# Altium schematic sheet Alimentation.SchDoc
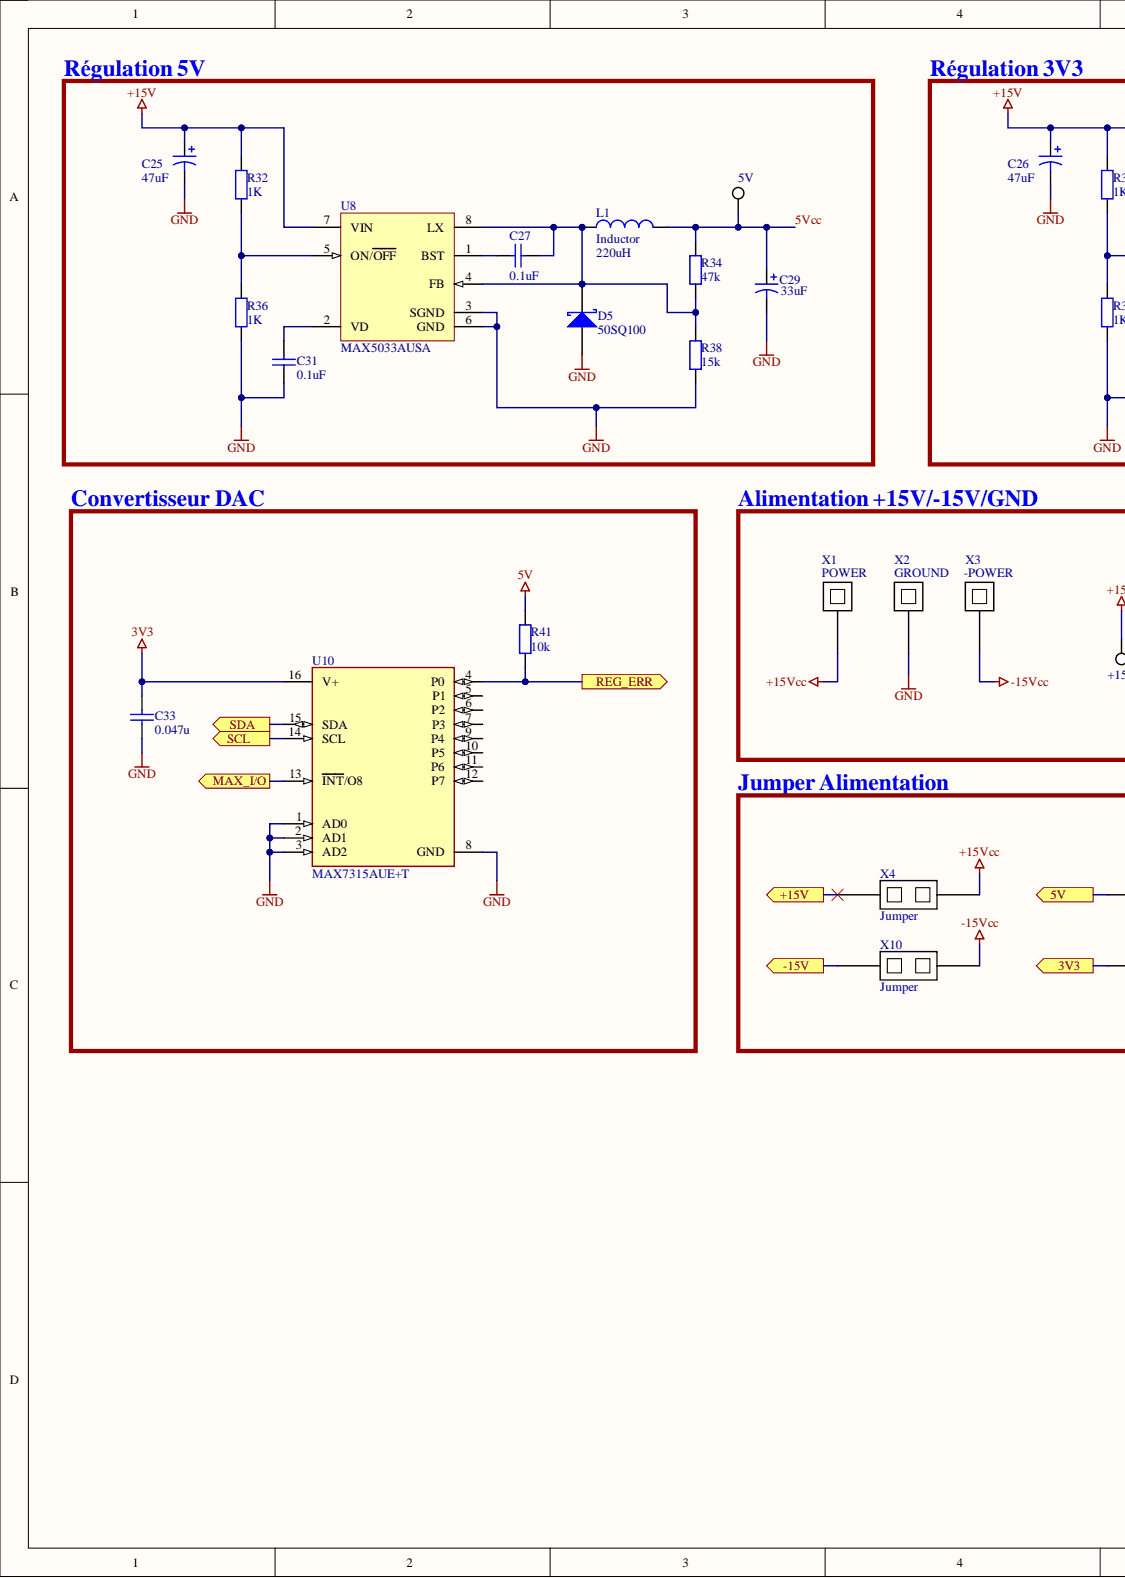
[diagram p0: Alimentation.SchDoc, left]
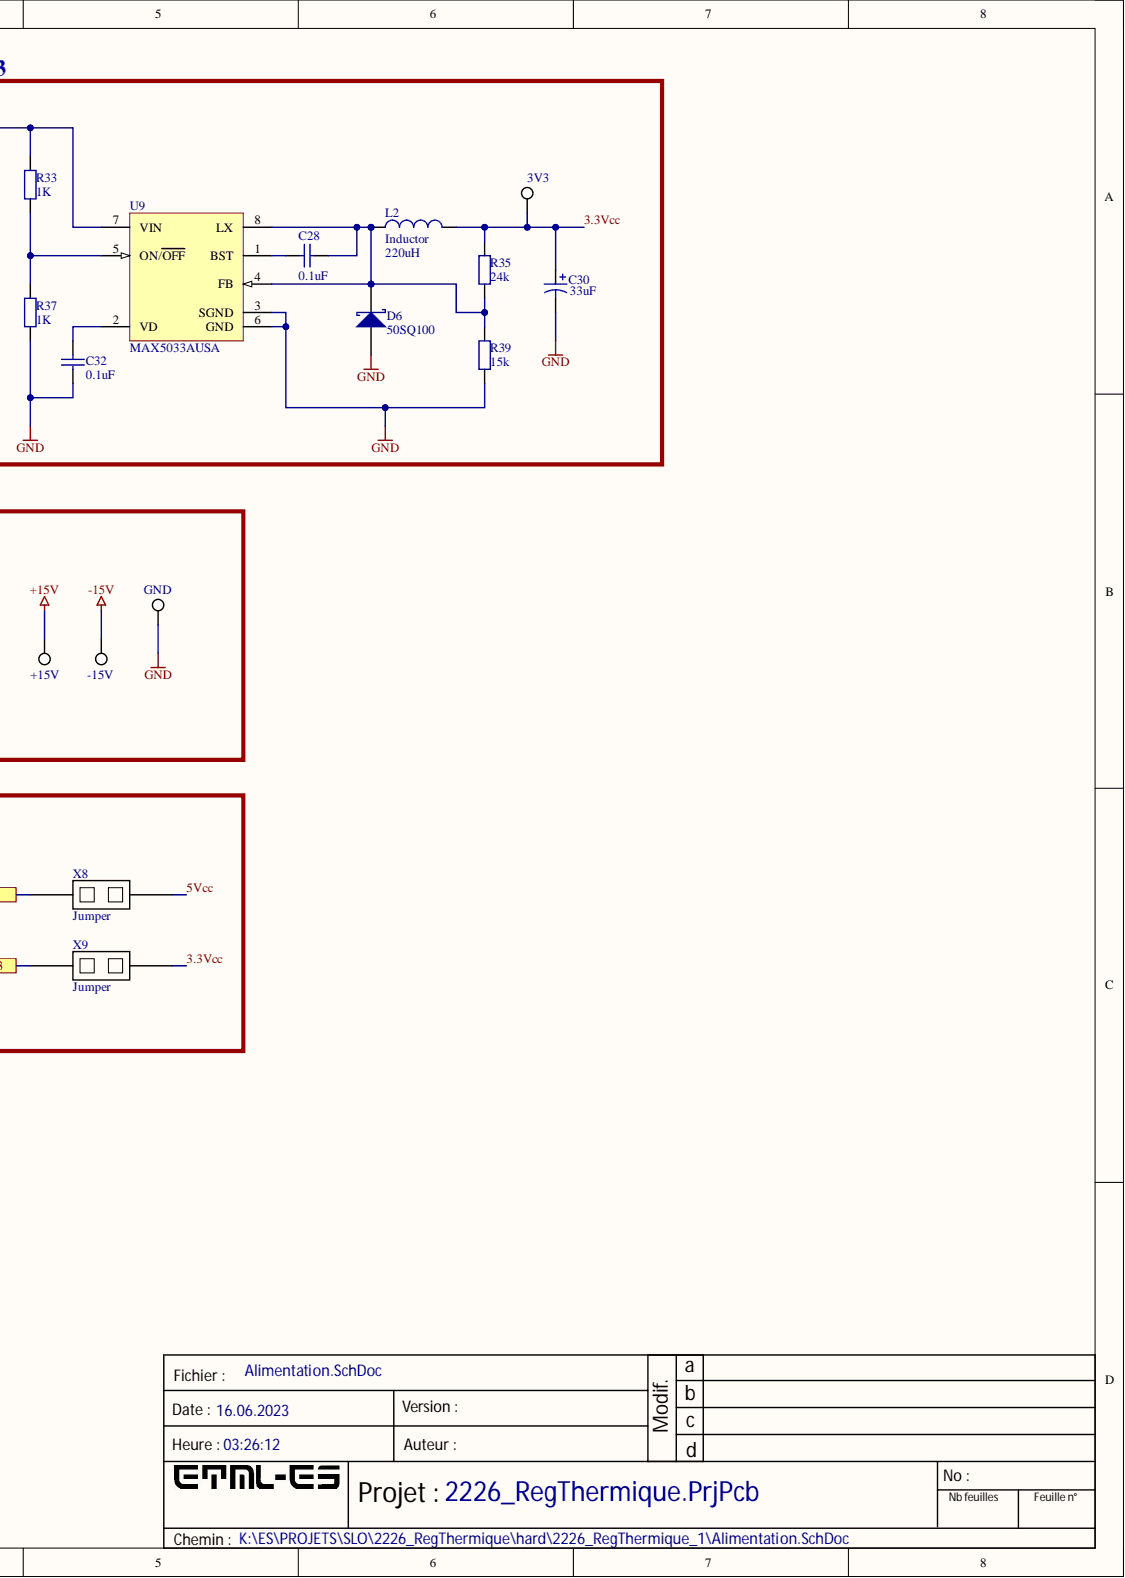
[diagram p1: Alimentation.SchDoc, right]

## Parts
3V3  REGP  [KSTN-5019_V]  (value not drawn)
5V  REGP  [KSTN-5019_V]  (value not drawn)
+15V  REGP  [KSTN-5019_V]  (value not drawn)
-15V  REGP  [KSTN-5019_V]  (value not drawn)
C25  value 47uF  [C0805]  (hidden comment: Cap Pol1)
C26  value 47uF  [C0805]  (hidden comment: Cap Pol1)
C27  value 0.1uF  [C0805]  (hidden comment: Cap)
C28  value 0.1uF  [C0805]  (hidden comment: Cap)
C29  value 33uF  [C0805]  (hidden comment: Cap Pol1)
C30  value 33uF  [C0805]  (hidden comment: Cap Pol1)
C31  value 0.1uF  [C0805]  (hidden comment: Cap)
C32  value 0.1uF  [C0805]  (hidden comment: Cap)
C33  value 0.047u  [C0805]  (hidden comment: Cap)
D5  50SQ100  [SODFL2513X70N]
D6  50SQ100  [SODFL2513X70N]
GND  REGP  [KSTN-5019_V]  (value not drawn)
L1  Inductor  value 220uH  [SDE0604A101K]
L2  Inductor  value 220uH  [SDE0604A101K]
R32  value 1K  [6-0805_N]  (hidden comment: Res2)
R33  value 1K  [6-0805_N]
R34  value 47k  [6-0805_N]  (hidden comment: Res2)
R35  value 24k  [6-0805_N]  (hidden comment: Res2)
R36  value 1K  [6-0805_N]  (hidden comment: Res2)
R37  value 1K  [6-0805_N]
R38  value 15k  [6-0805_N]  (hidden comment: Res2)
R39  value 15k  [6-0805_N]  (hidden comment: Res2)
R41  value 10k  [6-0805_N]  (hidden comment: Res2)
U8  MAX5033AUSA  [S8-5_N]
U9  MAX5033AUSA  [S8-5_N]
U10  MAX7315AUE+T  [FP-U16-2-MFG]
X1  POWER  [PIN1]
X2  GROUND  [PIN1]
X3  -POWER  [PIN1]
X4  Jumper  [HDR1X2]
X8  Jumper  [HDR1X2]
X9  Jumper  [HDR1X2]
X10  Jumper  [HDR1X2]

## Ports
3V3, 5V, +15V, -15V, MAX_I/O, REG_ERR, SCL, SDA

## Nets
3V3: C33.2 U10.16(V+)
5V: R41.2
+15V: +15V.1 C25.1 C26.1 R32.2 R33.2 U8.7(VIN) U9.7(VIN)
+15Vcc: X1.1 X4.2
-15V: -15V.1
-15Vcc: X3.1 X10.2
GND: C25.2 C26.2 C29.2 C30.2 C31.2 C32.2 C33.1 D5.1 D6.1 GND.1 R36.1 R37.1 R38.1 R39.1 U8.3(SGND) U8.6(GND) U9.3(SGND) U9.6(GND) U10.1(AD0) U10.2(AD1) U10.3(AD2) U10.8(GND) X2.1
3.3Vcc: 3V3.1 C30.1 L2.2 R35.2 X9.2
5Vcc: 5V.1 C29.1 L1.2 R34.2 X8.2
MAX_I/O: U10.13(INT/O8) ; port MAX_I/O
REG_ERR: R41.1 U10.4(P0) ; port REG_ERR
SCL: U10.14(SCL) ; port SCL
SDA: U10.15(SDA) ; port SDA
NetC27_1: C27.1 U8.1(BST)
NetC27_2: C27.2 D5.2 L1.1 R34.1 R38.2 U8.4(FB) U8.8(LX)
NetC28_1: C28.1 U9.1(BST)
NetC28_2: C28.2 D6.2 L2.1 R35.1 R39.2 U9.4(FB) U9.8(LX)
NetC31_1: C31.1 U8.2(VD)
NetC32_1: C32.1 U9.2(VD)
NetR32_1: R32.1 R36.2 U8.5(ON/OFF)
NetR33_1: R33.1 R37.2 U9.5(ON/OFF)
NetX4_1: X4.1
NetX8_1: X8.1 ; port 5V
NetX9_1: X9.1 ; port 3V3
NetX10_1: X10.1

Not connected: U10 5(P1) 6(P2) 7(P3) 9(P4) 10(P5) 11(P6) 12(P7)

## Library data of the parts
3V3 5V +15V -15V GND = CMP-1672-00008-1: Test Point, 1 Position SMD, RoHS, Tape and Reel; Component Kind: Standard; ComponentLink1Description: Manufacturer URL; ComponentLink1URL: http://www.keyelco.com/; ComponentLink2Description: Datasheet; ComponentLink2URL: http://www.keyelco.com/product-pdf.cfm?p=1357; Contact Plating: Silver; DatasheetVersion: 03/2014; Library Name: Altium Content Vault; Mount: Surface Mount; Mounting Technology: Surface Mount; Package Quantity: 1000; PackageDescription: 1-Pin Surface Mount Device, Body 3.81 x 2.03 mm; PackageReference: 5019; Packaging: Tape and Reel; Pins: 1; RoHSCompliant: True
C25 C26 = Cap Pol1: Cap Pol 47uF; Component Kind: Standard; LatestRevisionDate: 17-Jul-2002; LatestRevisionNote: Re-released for DXP Platform.; Library Name: Miscellaneous Devices.IntLib; PackageDescription: Polarized Capacitor (Radial); 2 Leads; PackageReference: RB7.6-15; Published: 8-Jun-2000; Signal Integrity: Cap Pol; Simulation: CAP; Publisher: Altium Limited
C27 C28 C31 C32 = Cap: Cap 100nF; Component Kind: Standard; LatestRevisionDate: 17-Jul-2002; LatestRevisionNote: Re-released for DXP Platform.; Library Name: Miscellaneous Devices.IntLib; PackageDescription: Radial Cap, Thru-Hole; 2 Leads; 0.3 in Pin Spacing; PackageReference: RAD-0.3; Published: 8-Jun-2000; Signal Integrity: Cap; Simulation: CAP; Publisher: Altium Limited
C29 C30 = Cap Pol1: Cap Pol 33uF; Component Kind: Standard; LatestRevisionDate: 17-Jul-2002; LatestRevisionNote: Re-released for DXP Platform.; Library Name: Miscellaneous Devices.IntLib; PackageDescription: Polarized Capacitor (Radial); 2 Leads; PackageReference: RB7.6-15; Published: 8-Jun-2000; Signal Integrity: Cap Pol; Simulation: CAP; Publisher: Altium Limited
C33 = Cap: Cap 0.047uF; Component Kind: Standard; LatestRevisionDate: 17-Jul-2002; LatestRevisionNote: Re-released for DXP Platform.; Library Name: Miscellaneous Devices.IntLib; PackageDescription: Radial Cap, Thru-Hole; 2 Leads; 0.3 in Pin Spacing; PackageReference: RAD-0.3; Published: 8-Jun-2000; Signal Integrity: Cap; Simulation: CAP; Publisher: Altium Limited
D5 D6 = D Schottky: Schottky Diode 50SQ100; Code_JEDEC: DO-214-AA; Component Kind: Standard; LatestRevisionDate: 17-Jul-2002; LatestRevisionNote: Re-released for DXP Platform.; Library Name: Miscellaneous Devices.IntLib; PackageDescription: DO-214-AA/SMB; 2 C-Bend Leads; Body 5.3 x 3.6 mm, inc. leads (LxW); PackageReference: SMB; Published: 8-Jun-2000; Signal Integrity: D Schottky; Simulation: SKYDIODE; Publisher: Altium Limited
L1 L2 = Inductor: Inductor 220uH; Code_IEC: 1005; Code_JEITA: 0402; Component Kind: Standard; LatestRevisionDate: 29-May-2009; LatestRevisionNote: IPC-7351 Footprint Added.; Library Name: Miscellaneous Devices.IntLib; PackageDescription: Chip Inductor; PackageReference: 0402-A; Published: 8-Jun-2000; Signal Integrity: Inductor; Simulation: INDUCTOR; Publisher: Altium Limited
R32 R33 R36 R37 = Res2: Res 1K; Component Kind: Standard; LatestRevisionDate: 17-Jul-2002; LatestRevisionNote: Re-released for DXP Platform.; Library Name: Miscellaneous Devices.IntLib; PackageDescription: Axial Device, Thru-Hole; 2 Leads; 0.4 in Pin Spacing; PackageReference: AXIAL-0.4; Published: 8-Jun-2000; Signal Integrity: Res; Simulation: RESISTOR; Publisher: Altium Limited
R34 R38 = Res2: Resistor; Component Kind: Standard; LatestRevisionDate: 17-Jul-2002; LatestRevisionNote: Re-released for DXP Platform.; Library Name: Miscellaneous Devices.IntLib; PackageDescription: Axial Device, Thru-Hole; 2 Leads; 0.4 in Pin Spacing; PackageReference: AXIAL-0.4; Published: 8-Jun-2000; Signal Integrity: Res; Simulation: RESISTOR; Publisher: Altium Limited
R35 = Res2: Res 24K; Component Kind: Standard; LatestRevisionDate: 17-Jul-2002; LatestRevisionNote: Re-released for DXP Platform.; Library Name: Miscellaneous Devices.IntLib; PackageDescription: Axial Device, Thru-Hole; 2 Leads; 0.4 in Pin Spacing; PackageReference: AXIAL-0.4; Published: 8-Jun-2000; Signal Integrity: Res; Simulation: RESISTOR; Publisher: Altium Limited
R39 = Res2: Res 15K; Component Kind: Standard; LatestRevisionDate: 17-Jul-2002; LatestRevisionNote: Re-released for DXP Platform.; Library Name: Miscellaneous Devices.IntLib; PackageDescription: Axial Device, Thru-Hole; 2 Leads; 0.4 in Pin Spacing; PackageReference: AXIAL-0.4; Published: 8-Jun-2000; Signal Integrity: Res; Simulation: RESISTOR; Publisher: Altium Limited
R41 = Res2: Res 10K; Component Kind: Standard; LatestRevisionDate: 17-Jul-2002; LatestRevisionNote: Re-released for DXP Platform.; Library Name: Miscellaneous Devices.IntLib; PackageDescription: Axial Device, Thru-Hole; 2 Leads; 0.4 in Pin Spacing; PackageReference: AXIAL-0.4; Published: 8-Jun-2000; Signal Integrity: Res; Simulation: RESISTOR; Publisher: Altium Limited
U8 U9 = CMP-0246-00246-1: 500mA, 76V, High-Efficiency, MAXPower(TM) Step-Down DC-DC Converter, 3.3V output, 8-Pin SOIC, 0°C to +85°C; Code_IPC: SOIC127P600-8; Code_JEDEC: MS-012-AA; Component Kind: Standard; ComponentLink1Description: Manufacturer URL; ComponentLink1URL: http://www.maxim-ic.com; ComponentLink2Description: Datasheet; ComponentLink2URL: http://datasheets.maxim-ic.com/en/ds/MAX5033.pdf; ComponentLink3Description: Package Specification; ComponentLink3URL: http://pdfserv.maxim-ic.com/package_dwgs/21-0041.PDF; DatasheetVersion: Rev 5, May-2011; Library Name: Altium Content Vault; PackageDescription: 8-Pin Small-Outline IC, Narrow (0.15in), 1.27 mm Pitch, SOIC (N); PackageReference: S8-5; PackageVersion: revC, 2010
U10 = CMP-05690-000009-1: IC I/O EXPANDER I2C 8B 16TSSOP; Frequency: 400kHz; Number of I/Os: 8; Operating Supply Voltage: 3.6V; Output Current: 50mA; Power Dissipation: 1.176W; Case/Package: TSSOP; Component Kind: Standard; Interface: I2C; Lead Free: Lead Free; Library Name: Altium Content Vault; Max Frequency: 400kHz; Max Operating Temperature: 125°C; Min Operating Temperature: -40°C; Mount: Surface Mount; Number of Pins: 16; Package Quantity: 2500; Packaging: Tape and Reel; RoHS Compliant: Yes; Series: -
X1-X3 = Berg: CONN HEADER VERT 1POS; Component Kind: Standard; Supplier: Stock ES; Library Name: 2019_RegThermiqueMyRio.SchLib
X4 X8-X10 = Jumper: CONN HEADER VERT 2POS 2.54MM; Component Kind: Standard; Supplier: Mouser; Supplier Ref.: 710-61300211121; Supplier unit price: 0.119; Library Name: 2019_RegThermiqueMyRio.SchLib
